# Altium schematic sheet Patchkeeper_V2.0_PPG.SchDoc(Patchkeeper_V2.0_PPG)
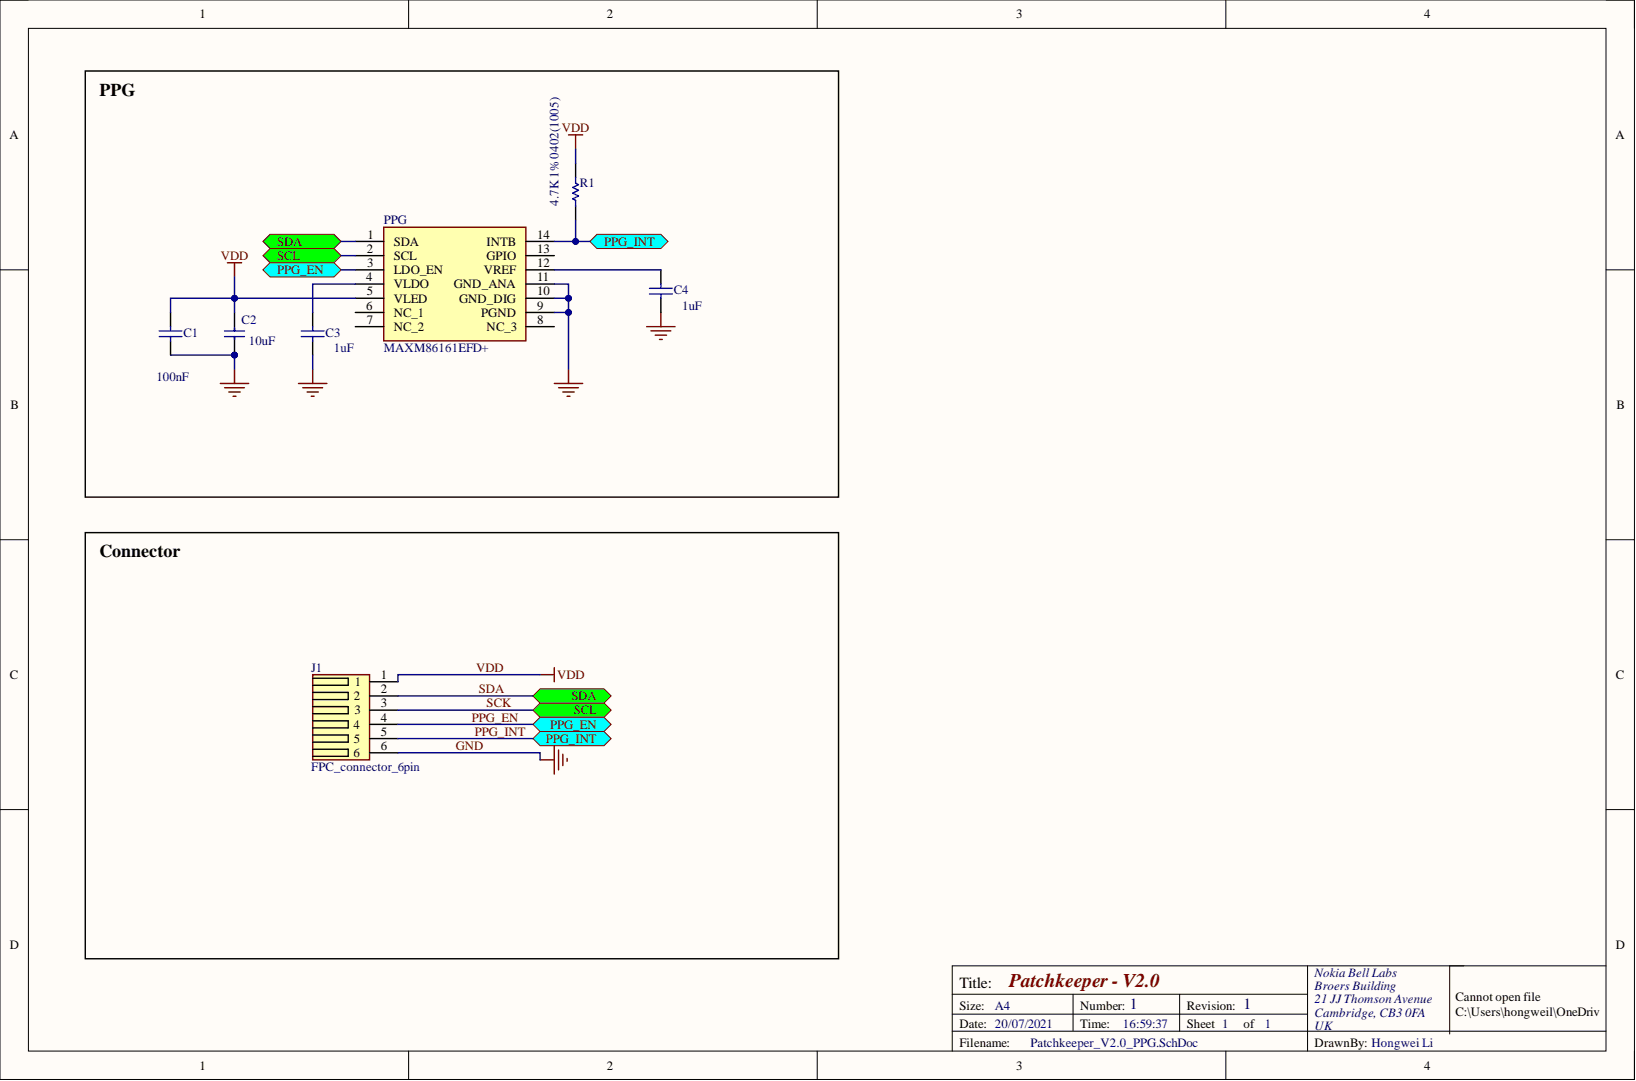
[diagram p0: Patchkeeper_V2.0_PPG.SchDoc]

## Parts
C1  100nF  [CAPC0402(1005)60_L]
C2  10u  Capacitance: 10uF  [CAPC0603(1608)100_L]
C3  1uF  [CAPC0402(1005)60_L]
C4  1uF  [CAPC0402(1005)60_L]
J1  FPC_connector_6pin  [FPC_0.5mm_Connector_6pin]
PPG  MAXM86161EFD+  [MAXM86161EFD]
R1  4.7K 1% 0402(1005)  [RESC1005X40X25LL05T05]

## Ports
PPG_EN, PPG_INT, SCL, SDA

## Nets
GND: C1.1 C2.1 C3.1 C4.1 J1.6 PPG.9(PGND) PPG.10(GND_DIG) PPG.11(GND_ANA)
PPG_EN: J1.4 PPG.3(LDO_EN) ; port PPG_EN
PPG_INT: J1.5 PPG.14(INTB) R1.1 ; port PPG_INT
SCK: J1.3 PPG.2(SCL) ; port SCL
SDA: J1.2 PPG.1(SDA) ; port SDA
VDD: C1.2 C2.2 J1.1 PPG.5(VLED) R1.2
NetC3_2: C3.2 PPG.4(VLDO)
NetC4_2: C4.2 PPG.12(VREF)

Not connected: PPG 6(NC_1) 7(NC_2) 8(NC_3) 13(GPIO)

## Library data of the parts
C1 = CAP_0402_100nF: CAP 100nF 16V ±10% 0402 (1005 Metric) Thickness 0.6mm SMD; Case-EIA: 0402; Case-Metric: 1005; Tolerance: Â±10%; Component Kind: Standard; Max Thickness: 0.6 mm; Rated Voltage: 16 V; Technology: SMT; Value: 100nF; Library Name: PatchKeeper.Schlib
C2 = CAP_0603_10uF: Capacitor, 10u, X5R, ±20%, 6.3V; Manufacturer: Murata; Manufacturer Part Number: GRM188R61A106KE69D; Tolerance: 10%; Voltage: 10V; Case Code (Imperial): 0603; Case Code (Metric): 1608; Case/Package: 0603; Category: Ceramic Capacitors; Component Kind: Standard; ComponentLink1Description: http://components.arrow.com/part/dataview/0323_07281717?src-supplier=Arrow+Europe; ComponentLink1URL: http://components.arrow.com/part/dataview/0323_07281717?src-supplier=Arrow+Europe; ComponentLink2Description: http://www.farnell.com/datasheets/1955682.pdf?src-supplier=Farnell; ComponentLink2URL: http://www.farnell.com/datasheets/1955682.pdf?src-supplier=Farnell; ComponentLink3Description: http://www.mouser.com/ds/2/281/c02e-2905.pdf?src-supplier=Mouser; ComponentLink3URL: http://www.mouser.com/ds/2/281/c02e-2905.pdf?src-supplier=Mouser; ComponentLink4Description: http://search.murata.co.jp/Ceramy/image/img/A01X/G101/ENG/GRM188R61A106KE69-01.pdf?src-supplier=Digi-Key; ComponentLink4URL: http://search.murata.co.jp/Ceramy/image/img/A01X/G101/ENG/GRM188R61A106KE69-01.pdf?src-supplier=Digi-Key; ComponentLink5Description: http://www.farnell.com/datasheets/1955682.pdf?src-supplier=Element14; ComponentLink5URL: http://www.farnell.com/datasheets/1955682.pdf?src-supplier=Element14; ComponentLink6Description: http://www.farnell.com/datasheets/1955682.pdf?src-supplier=Newark; ComponentLink6URL: http://www.farnell.com/datasheets/1955682.pdf?src-supplier=Newark; ComponentLink7Description: http://psearch.en.murata.com/capacitor/product/GRM188R61A106KE69%23.pdf?src-supplier=Avnet; ComponentLink7URL: http://psearch.en.murata.com/capacitor/product/GRM188R61A106KE69%23.pdf?src-supplier=Avnet; Depth: 0.8mm; Dielectric: X5R; Height: 0.8mm; Lead Free: Lead Free; Length: 1.6mm; Max Operating Temperature: 85°C; Min Operating Temperature: -55°C; Mount: Surface Mount; Packaging: Tape and Reel; Pricing 1: 1=0,22000, 10=0,14700, 50=0,08840, 100=0,07460, 500=0,05106, 1000=0,04516 (USD); REACH SVHC: No SVHC; RoHS: Yes; Stock 1: 2252553; Supplier 1: Digi-Key; Supplier Part Number 1: 490-10474-1-ND; Thickness: 0.037inch; Value: 10u; Voltage Rating: 10V; Voltage Rating (DC): 10V; Width: 0.031inch; Library Name: PatchKeeper.Schlib
C3 C4 = CAP_0402_1uF: CAP 1uF 16V ±10% 0402 (1005 Metric) Thickness 0.6mm SMD; Case-EIA: 0402; Case-Metric: 1005; Tolerance: Â±10%; Component Kind: Standard; Max Thickness: 0.6 mm; Rated Voltage: 16 V; Technology: SMT; Value: 1uF; Library Name: PatchKeeper.Schlib
J1 = FPC_connector_6pin: FFC/FPC Connector, 6 pin plug, pitch 0.5mm, 0.3mm strip; Assembly: Not Mounted; Component Kind: Net Tie; Library Name: PatchKeeper.Schlib
PPG = MAXM86161EFD+: Integrated Circuit; Manufacturer_Name: Maxim Integrated; Component Kind: Standard; Datasheet Link: https://datasheets.maximintegrated.com/en/ds/MAXM86161.pdf; Height: 1.5mm; Manufacturer_Part_Number: MAXM86161EFD+; Library Name: PatchKeeper.Schlib
R1 = RES_0402_4k7: 4.7K 0.063W 1% 0402 (1005 Metric)  SMD; Case-EIA: 0402; Case-Metric: 1005; Value: 4700.00 Ohm; Component Kind: Standard; Power: 0.063 W; Technology: SMT; Tolerance: 1 %; Library Name: PatchKeeper.Schlib
